# Altium schematic sheet max_amplificador.SchDoc
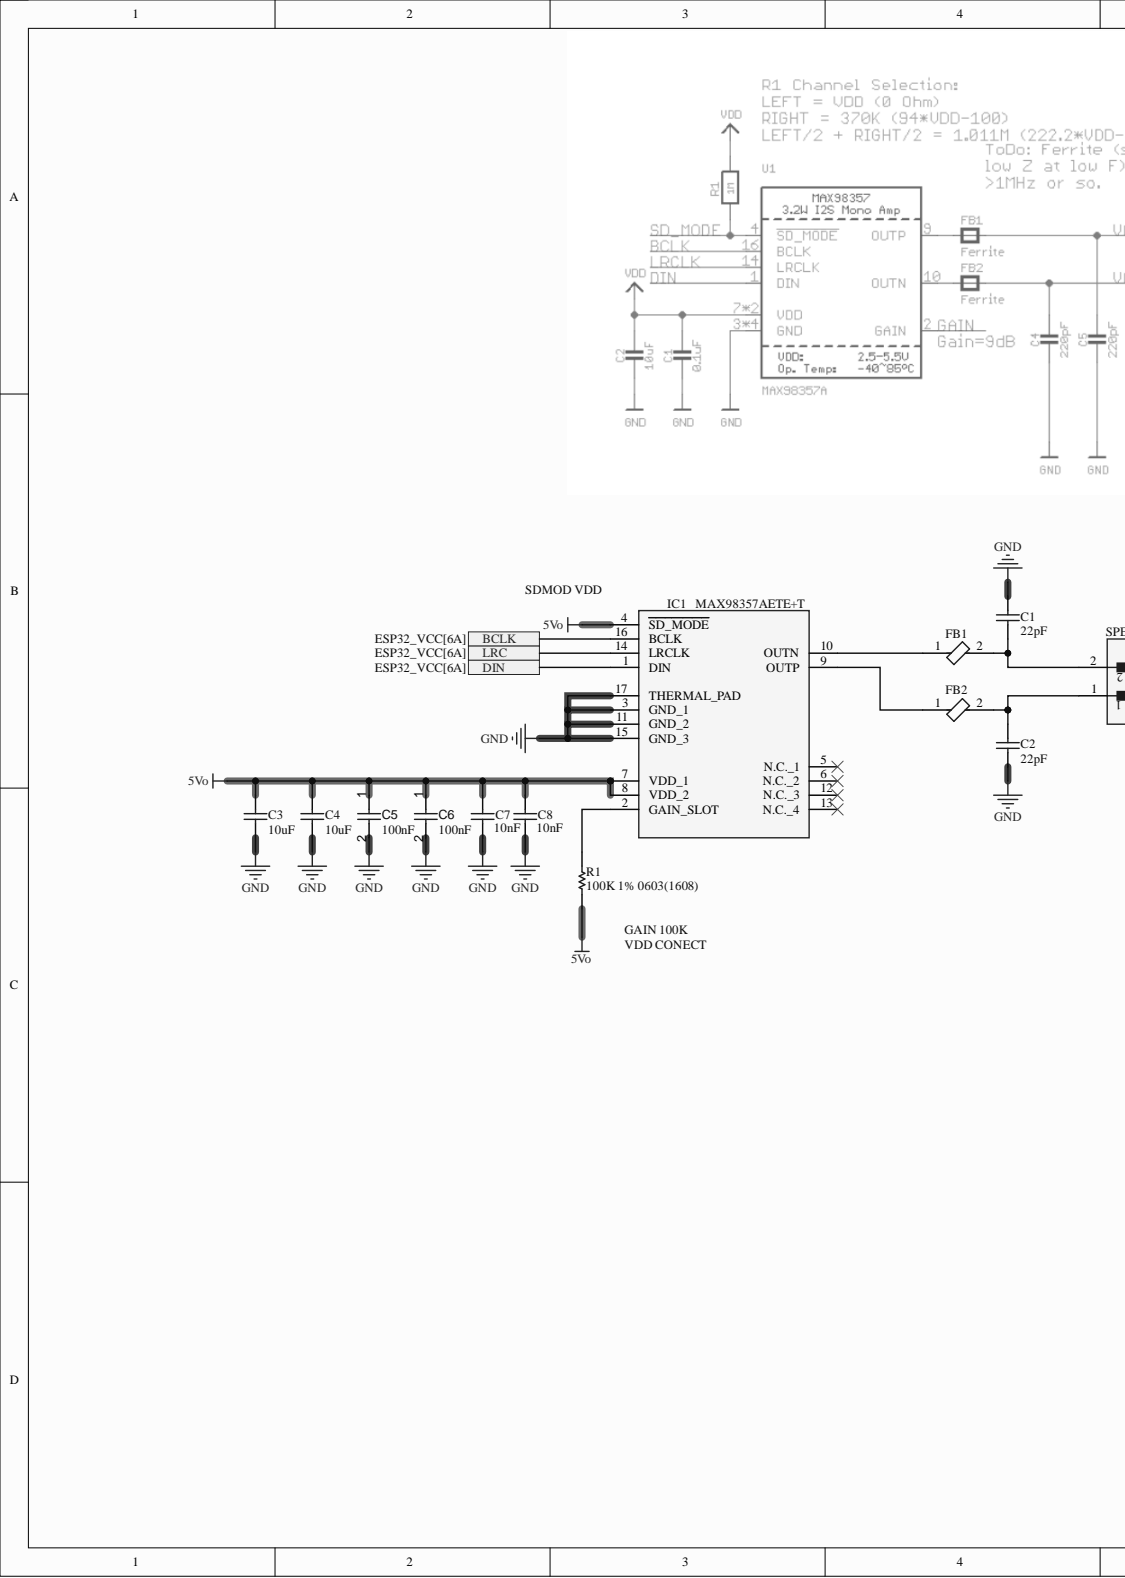
[diagram p0: max_amplificador.SchDoc, left]
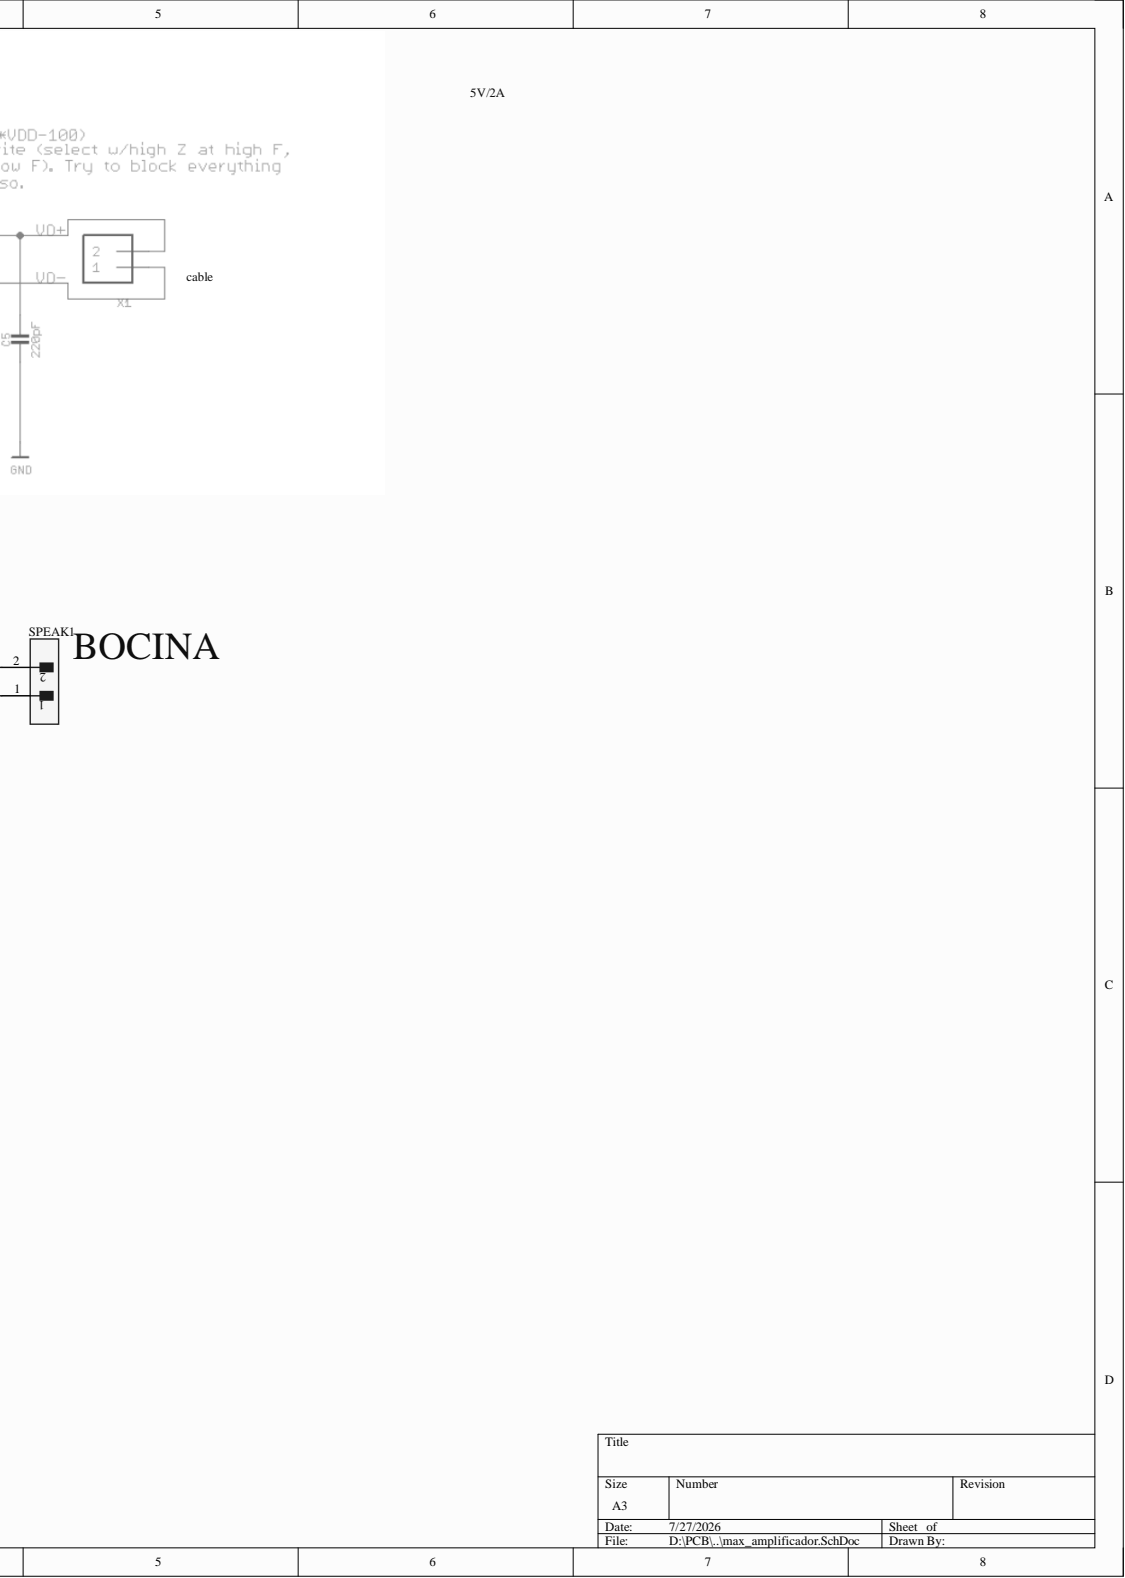
[diagram p1: max_amplificador.SchDoc, right]

## Parts
C1  value 22pF  [CAPC1608X90X35ML15T15]  (hidden comment: 06035A221J4T2A)
C2  value 22pF  [CAPC1608X90X35ML15T15]  (hidden comment: 06035A221J4T2A)
C3  value 10uF  [CAPC0603(1608)100_M]  (hidden comment: CAP 10uF 6.3V 0603(1608))
C4  value 10uF  [CAPC0603(1608)100_M]  (hidden comment: CAP 10uF 6.3V 0603(1608))
C5  value 100nF  [CAPC1005X56X25ML10T15]  (hidden comment: Capacitor 0.1 uF +/- 5% 16 V 0402)
C6  value 100nF  [CAPC1005X56X25ML10T15]  (hidden comment: Capacitor 0.1 uF +/- 5% 16 V 0402)
C7  value 10nF  [CAPC0603X33X15ML03T05]  (hidden comment: 0201ZC103JAT2A)
C8  value 10nF  [CAPC0603X33X15ML03T05]  (hidden comment: 0201ZC103JAT2A)
FB1  BLM21PG220SN1D  [FP-BLM21-0_2-t0_85-e0_5-IPC_C]  (value not drawn)
FB2  BLM21PG220SN1D  [FP-BLM21-0_2-t0_85-e0_5-IPC_C]  (value not drawn)
IC1  MAX98357AETE+T  [QFN50P300X300X80-17N]
R1  100K 1% 0603(1608)  [RESC0603(1608)_M]
SPEAK1  B2B-XH-A(LF)(SN)  [FP-B2B-XH-A_LF_SN-MFG]  (value not drawn)

## Ports
BCLK, DIN, LRC

## Nets
5Vo: C3.2 C4.2 C5.1 C6.1 C7.2 C8.2 IC1.4(SD_MODE) IC1.7(VDD_1) IC1.8(VDD_2) R1.1
GND: C1.2 C2.2 C3.1 C4.1 C5.2 C6.2 C7.1 C8.1 IC1.3(GND_1) IC1.11(GND_2) IC1.15(GND_3) IC1.17(THERMAL_PAD)
BCLK: IC1.16(BCLK) ; port BCLK
DIN: IC1.1(DIN) ; port DIN
LRC: IC1.14(LRCLK) ; port LRC
NetC1_1: C1.1 FB1.2 SPEAK1.2
NetC2_1: C2.1 FB2.2 SPEAK1.1
NetFB1_1: FB1.1 IC1.10(OUTN)
NetFB2_1: FB2.1 IC1.9(OUTP)
NetIC1_2: IC1.2(GAIN_SLOT) R1.2

Not connected: IC1 5(N.C._1) 6(N.C._2) 12(N.C._3) 13(N.C._4)

## Library data of the parts
C1 C2 = CMP-2006-04590-1: Manufacturer: AVX Interconnect / Elco; Component Kind: Standard; Library Name: Altium Content Vault; Part Number: 06035A221J4T2A
C3 C4 = CMP-1035-02796-1: CAP 10uF 6.3V ±10% 0603 (1608 Metric) Thickness 1mm SMD; Case-EIA: 0603; Case-Metric: 1608; Tolerance: Â±10%; Component Kind: Standard; Library Name: Altium Content Vault; Max Thickness: 1 mm; Rated Voltage: 6.3 V; Technology: SMT
C5 C6 = CMP-001-00001-6: Chip Capacitor, 0.1 uF, +/- 5%, 16 V, 0402 (1005 Metric); Capacitor Type: Ceramic; Voltage Rating: 16V; Case/Package: 0402; Component Kind: Standard; Max Operating Temperature: 125°C; Min Operating Temperature: -55°C; Mounting Technology: SM; Pins: 2; RoHS Compliant: True; Tolerance: 5%; Library Name: Carlos Christopher Carbajal Jordán
C7 C8 = CMP-2010-00529-1: Manufacturer: AVX Interconnect / Elco; Component Kind: Standard; Library Name: Altium Content Vault; Part Number: 0201ZC103JAT2A
FB1 FB2 = CMP-0686-00622-4: Chip Ferrite Bead, 0805, 22Ω @ 100MHz, 0.009Ω, 25%, 6A; Manufacturer Series: BLM21P; DC Resistance (DCR): 9mR; Impedance: 22R; Material: Steel; Number of Circuits: 1; Resistance: 10mR; Shielding: Unshielded; Case Code (Imperial): 0805; Case Code (Metric): 2012; Case/Package: 0805; Component Kind: Standard; Core Material: Ferrite; Current Rating: 6A; DC Current: 6A; DC Resistance-Max: 9mR; Depth: 1.25mm; Height: 0.85mm; Lead Free: Lead Free; Length: 2mm; Library Name: Altium Content Vault; Max Current Rating: 6A; Max DC Current: 6A; Max Operating Temperature: 125°C; Min Operating Temperature: -55°C; Number of Functions: 1; Number of Lines: 1; Number of Pins: 2; Package Quantity: 1; Packaging: Tape and Reel; Radiation Hardening: No; REACH SVHC: No SVHC; RoHS Compliant: Yes; Series: BLM21P; Terminal Finish: Tin; Termination: SMD/SMT; Test Frequency: 100MHz; Tolerance: 25%; Weight: 0.000194oz; Width: 1.25mm
IC1 = MAX98357AETE+T: Integrated Circuit; Manufacturer_Name: Analog Devices; Arrow Part Number: MAX98357AETE+T; Arrow Price/Stock: https://www.arrow.com/en/products/max98357aetet/analog-devices?utm_currency=USD&region=nac; Component Kind: Standard; Datasheet Link: https://datasheets.maximintegrated.com/en/ds/MAX98357A-MAX98357B.pdf; Height: 0.8mm; Library Name: MAX98357AETE+T.SchLib; Manufacturer_Part_Number: MAX98357AETE+T; Mouser Part Number: 700-MAX98357AETE+T; Mouser Price/Stock: https://www.mouser.co.uk/ProductDetail/Analog-Devices-Maxim-Integrated/MAX98357AETE%2bT?qs=AAveGqk956HhNpoJjF5x2g%3D%3D
R1 = CMP-1012-00736-1: 100K 0.1W 1% 0603 (1608 Metric)  SMD; Case-EIA: 0603; Case-Metric: 1608; Value: 100000.00 Ohm; Component Kind: Standard; Library Name: Altium Content Vault; Power: 0.1 W; Technology: SMT; Tolerance: 1 %
SPEAK1 = CMP-2000-05888-3: CONN HEADER VERT 2POS 2.5MM; Connector Type: Male Pin; Contact Resistance: 20mR; Current Rating: 3A; Insulation Resistance: 1GR; Max Voltage Rating (AC): 250V; Number of Contacts: 2; Wire/Cable Gauge: 22AWG; Color: Natural; Component Kind: Standard; Contact Material: Brass; Contact Plating: Tin; Contact Type: Male Pin; Depth: 5.75mm; Fastening Type: Detent; Housing Color: White; Housing Material: Polyamide; Lead Free: Lead Free; Lead Pitch: 2.5mm; Length: 7.4mm; Library Name: Altium Content Vault; Max Current Rating: 3A; Max Operating Temperature: 85°C; Max Voltage Rating (DC): 250V; Min Operating Temperature: -25°C; Mount: Through Hole; Number of Positions: 2; Number of Rows: 1; Orientation: Straight; Packaging: Bulk; Pitch: 2.5mm; REACH SVHC: No SVHC; Series: XH; Termination: Solder; Voltage Rating: 250V; Voltage Rating (AC): 250V
